# Altium schematic sheet LMS.SchDoc
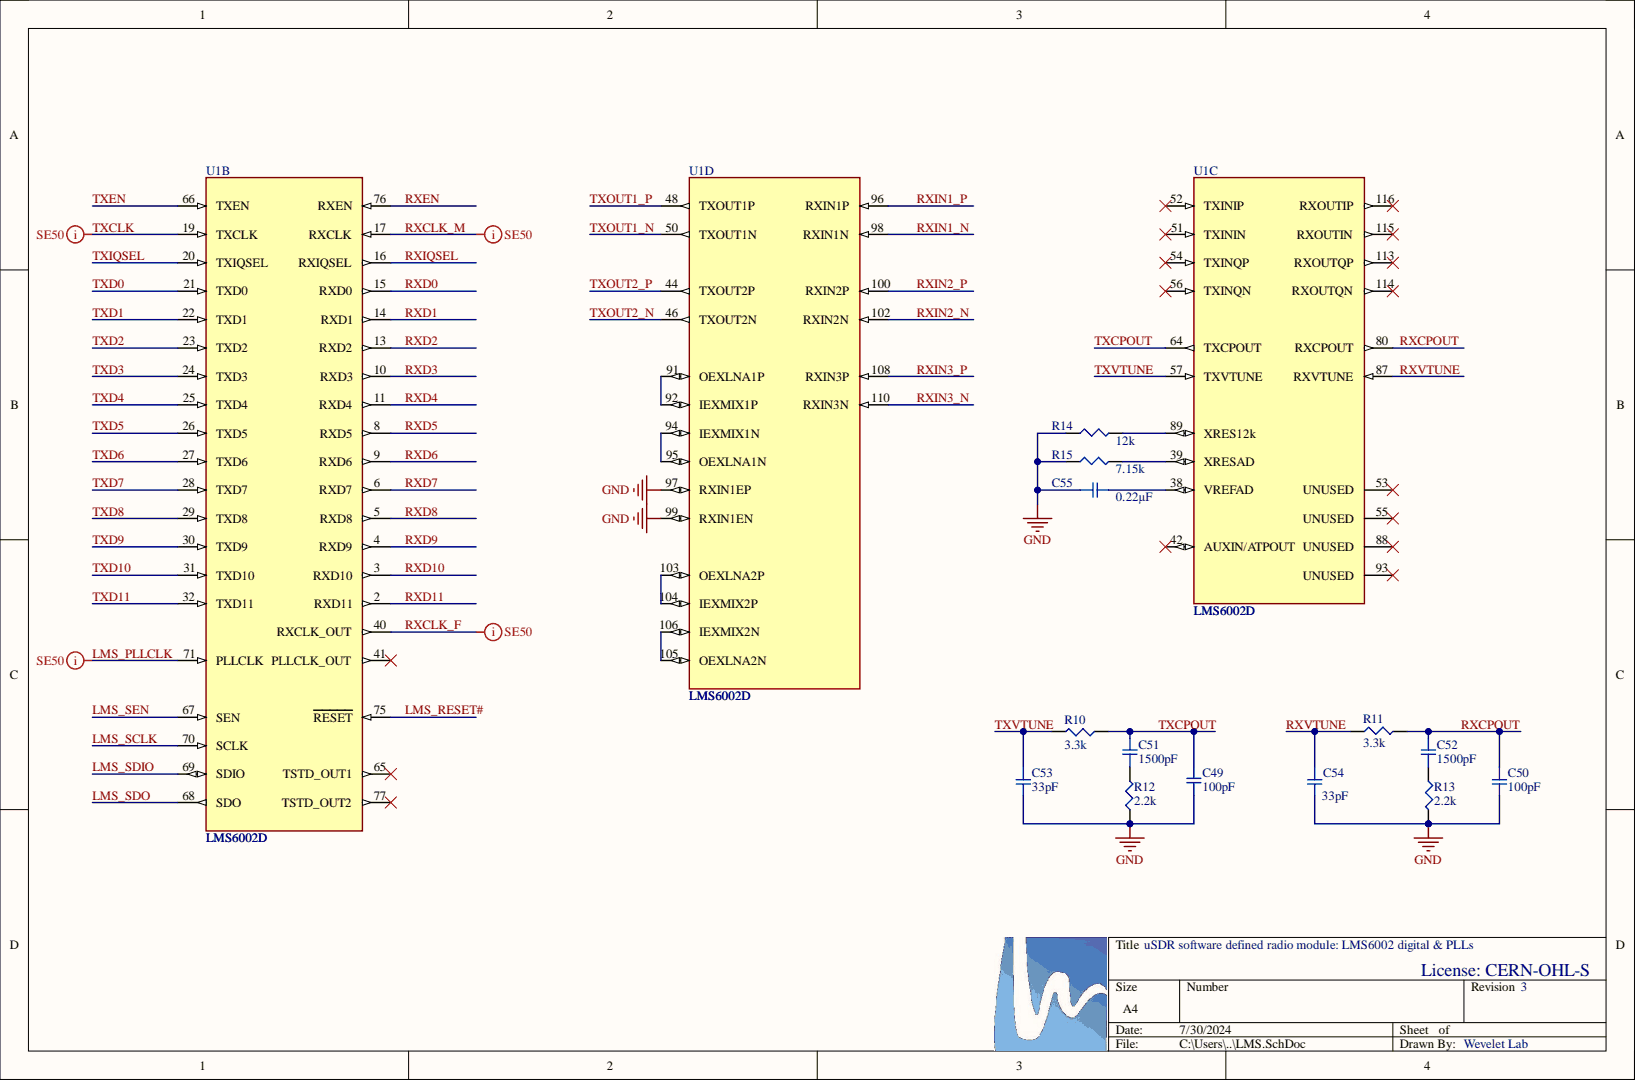
[diagram p0: LMS.SchDoc]

## Parts
C49  value 100pF  [SMD-0201C]  (hidden comment: GRM0335C1H101JA01D)
C50  value 100pF  [SMD-0201C]  (hidden comment: GRM0335C1H101JA01D)
C51  value 1500pF  [SMD-0201C]  (hidden comment: GRM033R71E152KA01D)
C52  value 1500pF  [SMD-0201C]  (hidden comment: GRM033R71E152KA01D)
C53  value 33pF  [SMD-0201C]  (hidden comment: GRM0335C1H330JA01D)
C54  value 33pF  [SMD-0201C]  (hidden comment: GRM0335C1H330JA01D)
C55  value 0.22µF  [SMD-0201C]  (hidden comment: GRM033C80J224ME90D)
R10  3.3k  [SMD-0201-RES]
R11  3.3k  [SMD-0201-RES]
R12  2.2k  [SMD-0201-RES]
R13  2.2k  [SMD-0201-RES]
R14  12k  [SMD-0201-RES]
R15  7.15k  [SMD-0201-RES]
U1  LMS6002D  [DQFN120(9x9/0.5mm)]  (parts B,C,D)

## Nets
GND: C49.1 C50.2 C53.2 C54.2 C55.1 R12.1 R13.2 R14.1 R15.1 U1.97(RXIN1EP) U1.99(RXIN1EN)
LMS_PLLCLK: U1.71(PLLCLK)
LMS_RESET#: U1.75(RESET)
LMS_SCLK: U1.70(SCLK)
LMS_SDIO: U1.69(SDIO)
LMS_SDO: U1.68(SDO)
LMS_SEN: U1.67(SEN)
RXCLK_F: U1.40(RXCLK_OUT)
RXCLK_M: U1.17(RXCLK)
RXCPOUT: C50.1 C52.2 R11.2 U1.80(RXCPOUT)
RXD0: U1.15(RXD0)
RXD1: U1.14(RXD1)
RXD2: U1.13(RXD2)
RXD3: U1.10(RXD3)
RXD4: U1.11(RXD4)
RXD5: U1.8(RXD5)
RXD6: U1.9(RXD6)
RXD7: U1.6(RXD7)
RXD8: U1.5(RXD8)
RXD9: U1.4(RXD9)
RXD10: U1.3(RXD10)
RXD11: U1.2(RXD11)
RXEN: U1.76(RXEN)
RXIN1_N: U1.98(RXIN1N)
RXIN1_P: U1.96(RXIN1P)
RXIN2_N: U1.102(RXIN2N)
RXIN2_P: U1.100(RXIN2P)
RXIN3_N: U1.110(RXIN3N)
RXIN3_P: U1.108(RXIN3P)
RXIQSEL: U1.16(RXIQSEL)
RXVTUNE: C54.1 R11.1 U1.87(RXVTUNE)
TXCLK: U1.19(TXCLK)
TXCPOUT: C49.2 C51.2 R10.1 U1.64(TXCPOUT)
TXD0: U1.21(TXD0)
TXD1: U1.22(TXD1)
TXD2: U1.23(TXD2)
TXD3: U1.24(TXD3)
TXD4: U1.25(TXD4)
TXD5: U1.26(TXD5)
TXD6: U1.27(TXD6)
TXD7: U1.28(TXD7)
TXD8: U1.29(TXD8)
TXD9: U1.30(TXD9)
TXD10: U1.31(TXD10)
TXD11: U1.32(TXD11)
TXEN: U1.66(TXEN)
TXIQSEL: U1.20(TXIQSEL)
TXOUT1_N: U1.50(TXOUT1N)
TXOUT1_P: U1.48(TXOUT1P)
TXOUT2_N: U1.46(TXOUT2N)
TXOUT2_P: U1.44(TXOUT2P)
TXVTUNE: C53.1 R10.2 U1.57(TXVTUNE)
NetC51_1: C51.1 R12.2
NetC52_1: C52.1 R13.1
NetC55_2: C55.2 U1.38(VREFAD)
NetR14_2: R14.2 U1.89(XRES12k)
NetR15_2: R15.2 U1.39(XRESAD)
NetU1_91: U1.91(OEXLNA1P) U1.92(IEXMIX1P)
NetU1_94: U1.94(IEXMIX1N) U1.95(OEXLNA1N)
NetU1_103: U1.103(OEXLNA2P) U1.104(IEXMIX2P)
NetU1_105: U1.105(OEXLNA2N) U1.106(IEXMIX2N)

Not connected: U1 41(PLLCLK_OUT) 42(AUXIN/ATPOUT) 51(TXININ) 52(TXINIP) 53(UNUSED) 54(TXINQP) 55(UNUSED) 56(TXINQN) 65(TSTD_OUT1) 77(TSTD_OUT2) 88(UNUSED) 93(UNUSED) 113(RXOUTQP) 114(RXOUTQN) 115(RXOUTIN) 116(RXOUTIP)

## Library data of the parts
C49 C50 = GRM0335C1H101JA01D: CAP CER 100PF 50V NP0 0201; Manufacturer: Murata Electronics North America; @ qty: 0; Minimum Quantity: 1; Temperature Coefficient: C0G, NP0; Tolerance: ±5%; Unit Price (USD): 0.1; Applications: General Purpose; Component Kind: Standard; Datasheets: http://www.murata.com/~/media/webrenewal/support/library/catalog/products/capacitor/mlcc/c02e.pdf; Digi-Key Part Number: 490-6113-1-ND; Factory Stock: 0; Failure Rate: Surface Mount, MLCC; Features: -; Height - Seated (Max): -; Image: //media.digikey.com/Renders/Murata%20Renders/ZRB-SERIES-0402.jpg; Mounting Type: 0201 (0603 Metric); Operating Temperature: -55°C ~ 125°C; Package / Case: 0.024" L x 0.012" W (0.60mm x 0.30mm); Packaging: Cut Tape (CT); Part Number: GRM0335C1H101JA01D; Part Status: Active; Quantity Available: 19110; Ratings: -; Series: GRM; Size / Dimension: -; Thickness (Max): -; Voltage: 50V; Library Name: USDR.IntLib
C51 C52 = GRM033R71E152KA01D: CAP CER 1500PF 25V X7R 0201; Manufacturer: Murata Electronics North America; @ qty: 0; Minimum Quantity: 1; Tolerance: ±10%; Unit Price (USD): 0.1; Applications: General Purpose; Component Kind: Standard; Datasheets: http://www.murata.com/~/media/webrenewal/support/library/catalog/products/capacitor/mlcc/c02e.pdf; Digi-Key Part Number: 490-3185-1-ND; Factory Stock: 0; Failure Rate: Surface Mount, MLCC; Features: -; Height - Seated (Max): 0.013" (0.33mm); Image: //media.digikey.com/Renders/Murata%20Renders/ZRB-SERIES-0402.jpg; Lead Spacing: -; Mounting Type: 0201 (0603 Metric); Operating Temperature: -55°C ~ 125°C; Package / Case: 0.024" L x 0.012" W (0.60mm x 0.30mm); Packaging: Cut Tape (CT); Part Number: GRM033R71E152KA01D; Part Status: Active; Quantity Available: 60053; Ratings: -; Series: GRM; Size / Dimension: -; Temperature Coefficient: X7R; Thickness (Max): -; Voltage: 25V; Library Name: USDR.IntLib
C53 C54 = GRM0335C1H330JA01D: CAP CER 33PF 50V NP0 0201; Manufacturer: Murata Electronics North America; @ qty: 0; Minimum Quantity: 1; Temperature Coefficient: C0G, NP0; Tolerance: ±5%; Unit Price (USD): 0.1; Applications: General Purpose; Component Kind: Standard; Datasheets: http://www.murata.com/~/media/webrenewal/support/library/catalog/products/capacitor/mlcc/c02e.pdf; Digi-Key Part Number: 490-6126-1-ND; Factory Stock: 0; Failure Rate: Surface Mount, MLCC; Features: -; Height - Seated (Max): -; Image: //media.digikey.com/Renders/Murata%20Renders/ZRB-SERIES-0402.jpg; Mounting Type: 0201 (0603 Metric); Operating Temperature: -55°C ~ 125°C; Package / Case: 0.024" L x 0.012" W (0.60mm x 0.30mm); Packaging: Cut Tape (CT); Part Number: GRM0335C1H330JA01D; Part Status: Active; Quantity Available: 29005; Ratings: -; Series: GRM; Size / Dimension: -; Thickness (Max): -; Voltage: 50V; Library Name: USDR.IntLib
C55 = GRM033C80J224ME90D: CAP CER 0.22UF 6.3V X6S 0201; Manufacturer: Murata Electronics North America; @ qty: 0; Minimum Quantity: 1; Tolerance: ±20%; Unit Price (USD): 0.1; Applications: General Purpose; Component Kind: Standard; Datasheets: http://www.murata.com/~/media/webrenewal/support/library/catalog/products/capacitor/mlcc/c02e.pdf; Digi-Key Part Number: 490-9982-1-ND; Factory Stock: 0; Failure Rate: Surface Mount, MLCC; Features: -; Height - Seated (Max): 0.013" (0.33mm); Image: //media.digikey.com/Renders/Murata%20Renders/ZRB-SERIES-0402.jpg; Lead Spacing: -; Mounting Type: 0201 (0603 Metric); Operating Temperature: -55°C ~ 105°C; Package / Case: 0.024" L x 0.012" W (0.60mm x 0.30mm); Packaging: Cut Tape (CT); Part Number: GRM033C80J224ME90D; Part Status: Active; Quantity Available: 381529; Ratings: -; Series: GRM; Size / Dimension: -; Temperature Coefficient: X6S; Thickness (Max): -; Voltage: 6.3V; Library Name: USDR.IntLib
R10 R11 = ERJ-1GEJ332C: RES SMD 3.3K OHM 5% 1/20W 0201; Manufacturer: Panasonic Electronic Components; @ qty: 1500; Factory Stock: 0; Minimum Quantity: 1; Power (Watts): 0.05W, 1/20W; Temperature Coefficient: ±200ppm/°C; Unit Price (USD): 0.00787; Component Kind: Standard; Composition: Thick Film; Datasheets: //media.digikey.com/pdf/Data%20Sheets/Panasonic%20Resistors%20Thermistors%20PDFs/ERJ%205%25.pdf; Digi-Key Part Number: P3.3KAGCT-ND; Failure Rate: -; Features: -; Height - Seated (Max): 0.010" (0.26mm); Image: //media.digikey.com/Renders/Panasonic%20Renders/ERJ-0201%20Pkg.jpg; Number of Terminations: 2; Operating Temperature: -55°C ~ 125°C; Package / Case: 0201 (0603 Metric); Packaging: Cut Tape (CT); Part Number: ERJ-1GEJ332C; Part Status: Active; Quantity Available: 45455; Series: ERJ; Size / Dimension: 0.024" L x 0.012" W (0.60mm x 0.30mm); Supplier Device Package: 0201; Tolerance: ±5%; Value: 3.3k; Library Name: USDR.IntLib
R12 R13 = ERJ-1GEJ222C: RES SMD 2.2K OHM 5% 1/20W 0201; Manufacturer: Panasonic Electronic Components; @ qty: 1500; Factory Stock: 0; Minimum Quantity: 1; Power (Watts): 0.05W, 1/20W; Temperature Coefficient: ±200ppm/°C; Unit Price (USD): 0.00787; Component Kind: Standard; Composition: Thick Film; Datasheets: //media.digikey.com/pdf/Data%20Sheets/Panasonic%20Resistors%20Thermistors%20PDFs/ERJ%205%25.pdf; Digi-Key Part Number: P2.2KAGCT-ND; Failure Rate: -; Features: -; Height - Seated (Max): 0.010" (0.26mm); Image: //media.digikey.com/Renders/Panasonic%20Renders/ERJ-0201%20Pkg.jpg; Number of Terminations: 2; Operating Temperature: -55°C ~ 125°C; Package / Case: 0201 (0603 Metric); Packaging: Cut Tape (CT); Part Number: ERJ-1GEJ222C; Part Status: Active; Quantity Available: 44807; Series: ERJ; Size / Dimension: 0.024" L x 0.012" W (0.60mm x 0.30mm); Supplier Device Package: 0201; Tolerance: ±5%; Value: 2.2k; Library Name: USDR.IntLib
R14 = ERJ-1GEJ123C: RES SMD 12K OHM 5% 1/20W 0201; Manufacturer: Panasonic Electronic Components; @ qty: 1500; Factory Stock: 0; Minimum Quantity: 1; Power (Watts): 0.05W, 1/20W; Temperature Coefficient: ±200ppm/°C; Unit Price (USD): 0.00787; Component Kind: Standard; Composition: Thick Film; Datasheets: //media.digikey.com/pdf/Data%20Sheets/Panasonic%20Resistors%20Thermistors%20PDFs/ERJ%205%25.pdf; Digi-Key Part Number: P12KAGCT-ND; Failure Rate: -; Features: -; Height - Seated (Max): 0.010" (0.26mm); Image: //media.digikey.com/Renders/Panasonic%20Renders/ERJ-0201%20Pkg.jpg; Number of Terminations: 2; Operating Temperature: -55°C ~ 125°C; Package / Case: 0201 (0603 Metric); Packaging: Cut Tape (CT); Part Number: ERJ-1GEJ123C; Part Status: Active; Quantity Available: 6101; Series: ERJ; Size / Dimension: 0.024" L x 0.012" W (0.60mm x 0.30mm); Supplier Device Package: 0201; Tolerance: ±5%; Value: 12k; Library Name: USDR.IntLib
R15 = ERJ-1GEF7151C: RES SMD 7.15K OHM 1% 1/20W 0201; Manufacturer: Panasonic Electronic Components; @ qty: 1500; Factory Stock: 0; Minimum Quantity: 1; Power (Watts): 0.05W, 1/20W; Temperature Coefficient: ±200ppm/°C; Unit Price (USD): 0.00933; Component Kind: Standard; Composition: Thick Film; Datasheets: //media.digikey.com/pdf/Data%20Sheets/Panasonic%20Resistors%20Thermistors%20PDFs/ERJ_G,R,E_Rev08.pdf; Digi-Key Part Number: P7.15KABCT-ND; Failure Rate: -; Features: -; Height - Seated (Max): 0.010" (0.26mm); Image: //media.digikey.com/Renders/Panasonic%20Renders/ERJ-0201%20Pkg.jpg; Number of Terminations: 2; Operating Temperature: -55°C ~ 125°C; Package / Case: 0201 (0603 Metric); Packaging: Cut Tape (CT); Part Number: ERJ-1GEF7151C; Part Status: Active; Quantity Available: 202258; Series: ERJ; Size / Dimension: 0.024" L x 0.012" W (0.60mm x 0.30mm); Supplier Device Package: 0201; Tolerance: ±1%; Value: 7.15k; Library Name: USDR.IntLib
U1 = LMS6002D: IC; Manufacturer: Lime Microsystems; Misc: Multi-band Multi-standard  Transceiver with Digital Interface; Component Kind: Standard; Name: LMS6002D; Library Name: USDR.IntLib
